# Altium schematic sheet CW521_BallisticGel_Main.SchDoc
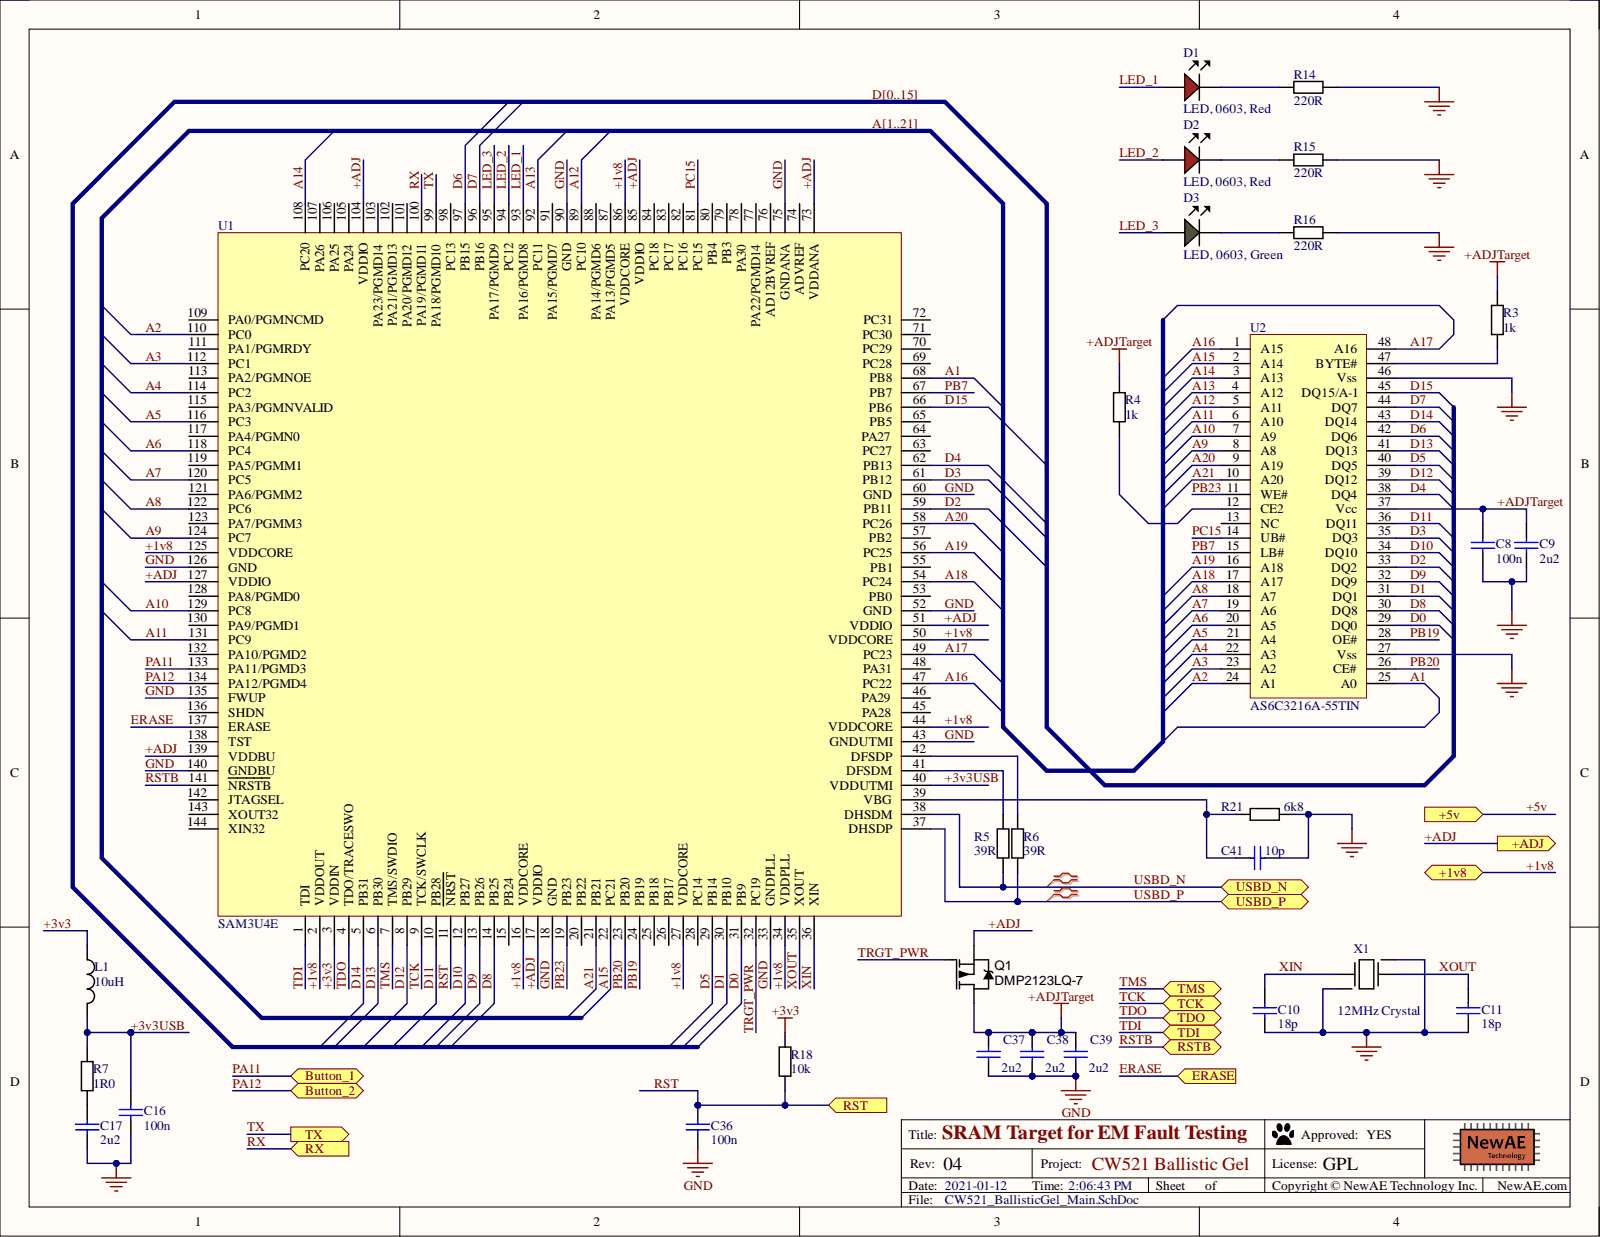
[diagram p0: CW521_BallisticGel_Main.SchDoc]

## Parts
C8  100n  [0603]
C9  2u2  [0603]
C10  18p  [0402]
C11  18p  [0402]
C16  100n  [0603]
C17  2u2  [0603]
C36  100n  [0603]
C37  2u2  [0603]
C38  2u2  [0603]
C39  2u2  [0603]
C41  10p  [0603]
D1  LED, 0603, Red  [LED-0603-RED]
D2  LED, 0603, Red  [LED-0603-RED]
D3  LED, 0603, Green  [LED-0603-GREEN]
L1  10uH  [0603]
Q1  DMP2123LQ-7  [SOT23]
R3  1k  [0603]
R4  1k  [0603]
R5  39R  [0603]
R6  39R  [0603]
R7  1R0  [0603]
R14  220R  [0603]
R15  220R  [0603]
R16  220R  [0603]
R18  10k  [0603]
R21  6k8  [0603]
U1  SAM3U4E  [LQFP144]
U2  AS6C3216A-55TIN  [TSSOP-48]
X1  12MHz Crystal  Component Group: Crystal  [ABM8G]

## Ports
+1v8, +5v, +ADJ, Button_1, Button_2, ERASE, RST, RSTB, RX, TCK, TDI, TDO, TMS, TX, USBD_N, USBD_P

## Nets
+1v8: U1.2(VDDOUT) U1.16(VDDCORE) U1.27(VDDCORE) U1.34(VDDPLL) U1.44(VDDCORE) U1.50(VDDCORE) U1.86(VDDCORE) U1.125(VDDCORE) ; port +1v8
+3v3: L1.1 R18.1 U1.3(VDDIN)
+3v3USB: C16.1 L1.2 R7.1 U1.40(VDDUTMI)
+ADJ: Q1.2 U1.17(VDDIO) U1.51(VDDIO) U1.73(VDDANA) U1.85(VDDIO) U1.104(VDDIO) U1.127(VDDIO) U1.139(VDDBU) ; port +ADJ
+ADJTarget: C8.1 C9.1 C37.1 C38.1 C39.1 Q1.3 R3.1 R4.1 U2.37(Vcc)
A1: U1.68(PB8) U2.25(A0)  [also labelled A]
A2: U1.110(PC0) U2.24(A1)  [also labelled A]
A3: U1.112(PC1) U2.23(A2)  [also labelled A]
A4: U1.114(PC2) U2.22(A3)  [also labelled A]
A5: U1.116(PC3) U2.21(A4)  [also labelled A]
A6: U1.118(PC4) U2.20(A5)  [also labelled A]
A7: U1.120(PC5) U2.19(A6)  [also labelled A]
A8: U1.122(PC6) U2.18(A7)  [also labelled A]
A9: U1.124(PC7) U2.8(A8)  [also labelled A]
A10: U1.129(PC8) U2.7(A9)  [also labelled A]
A11: U1.131(PC9) U2.6(A10)  [also labelled A]
A12: U1.89(PC10) U2.5(A11)  [also labelled A]
A13: U1.92(PC11) U2.4(A12)  [also labelled A]
A14: U1.108(PC20) U2.3(A13)  [also labelled A]
A15: U1.22(PC21) U2.2(A14)  [also labelled A]
A16: U1.47(PC22) U2.1(A15)  [also labelled A]
A17: U1.49(PC23) U2.48(A16)  [also labelled A]
A18: U1.54(PC24) U2.17(A17)  [also labelled A]
A19: U1.56(PC25) U2.16(A18)  [also labelled A]
A20: U1.58(PC26) U2.9(A19)  [also labelled A]
A21: U1.21(PB21) U2.10(A20)  [also labelled A]
D0: U1.31(PB9) U2.29(DQ0)  [also labelled D]
D1: U1.30(PB10) U2.31(DQ1)  [also labelled D]
D2: U1.59(PB11) U2.33(DQ2)  [also labelled D]
D3: U1.61(PB12) U2.35(DQ3)  [also labelled D]
D4: U1.62(PB13) U2.38(DQ4)  [also labelled D]
D5: U1.29(PB14) U2.40(DQ5)  [also labelled D]
D6: U1.97(PB15) U2.42(DQ6)  [also labelled D]
D7: U1.96(PB16) U2.44(DQ7)  [also labelled D]
D8: U1.14(PB25) U2.30(DQ8)  [also labelled D]
D9: U1.13(PB26) U2.32(DQ9)  [also labelled D]
D10: U1.12(PB27) U2.34(DQ10)  [also labelled D]
D11: U1.10(PB28) U2.36(DQ11)  [also labelled D]
D12: U1.8(PB29) U2.39(DQ12)  [also labelled D]
D13: U1.6(PB30) U2.41(DQ13)  [also labelled D]
D14: U1.5(PB31) U2.43(DQ14)  [also labelled D]
D15: U1.66(PB6) U2.45(DQ15/A-1)  [also labelled D]
ERASE: U1.137(ERASE) ; port ERASE
GND: C8.2 C9.2 C10.2 C11.2 C16.2 C17.2 C36.2 C37.2 C38.2 C39.2 C41.2 R14.2 R15.2 R16.2 R21.2 U1.18(GND) U1.33(GNDPLL) U1.43(GNDUTMI) U1.52(GND) U1.60(GND) U1.75(GNDANA) U1.90(GND) U1.126(GND) U1.135(FWUP) U1.140(GNDBU) U2.27(Vss) U2.46(Vss) X1.2 X1.4
LED_1: D1.A U1.93(PA16/PGMD8)
LED_2: D2.A U1.94(PC12)
LED_3: D3.A U1.95(PA17/PGMD9)
PA11: U1.133(PA11/PGMD3) ; port Button_1
PA12: U1.134(PA12/PGMD4) ; port Button_2
PB7: U1.67(PB7) U2.15(LB#)
PB19: U1.24(PB19) U2.28(OE#)
PB20: U1.23(PB20) U2.26(CE#)
PB23: U1.19(PB23) U2.11(WE#)
PC15: U1.81(PC15) U2.14(UB#)
RST: C36.1 R18.2 U1.11(NRST) ; port RST
RSTB: U1.141(NRSTB) ; port RSTB
RX: U1.100(PA19/PGMD11) ; port RX
TCK: U1.9(TCK/SWCLK) ; port TCK
TDI: U1.1(TDI) ; port TDI
TDO: U1.4(TDO/TRACESWO) ; port TDO
TMS: U1.7(TMS/SWDIO) ; port TMS
TRGT_PWR: Q1.1 U1.32(PC19)
TX: U1.99(PA18/PGMD10) ; port TX
USBD_N: R5.2 U1.38(DHSDM) ; port USBD_N
USBD_P: R6.2 U1.37(DHSDP) ; port USBD_P
XIN: C10.1 U1.36(XIN) X1.1
XOUT: C11.1 U1.35(XOUT) X1.3
NetC17_1: C17.1 R7.2
NetC41_1: C41.1 R21.1 U1.39(VBG)
NetD1_K: D1.K R14.1
NetD2_K: D2.K R15.1
NetD3_K: D3.K R16.1
NetR3_2: R3.2 U2.47(BYTE#)
NetR4_2: R4.2 U2.12(CE2)
NetR5_1: R5.1 U1.41(DFSDM)
NetR6_1: R6.1 U1.42(DFSDP)
+5v: ; port +5v

Not connected: U1 15(PB24) 20(PB22) 25(PB18) 26(PB17) 28(PC14) 45(PA28) 46(PA29) 48(PA31) 53(PB0) 55(PB1) 57(PB2) 63(PC27) 64(PA27) 65(PB5) 69(PC28) 70(PC29) 71(PC30) 72(PC31) 74(ADVREF) 76(AD12BVREF) 77(PA22/PGMD14) 78(PA30) 79(PB3) 80(PB4) 82(PC16) 83(PC17) 84(PC18) 87(PA13/PGMD5) 88(PA14/PGMD6) 91(PA15/PGMD7) 98(PC13) 101(PA20/PGMD12) 102(PA21/PGMD13) 103(PA23/PGMD14) 105(PA24) 106(PA25) 107(PA26) 109(PA0/PGMNCMD) 111(PA1/PGMRDY) 113(PA2/PGMNOE) 115(PA3/PGMNVALID) 117(PA4/PGMN0) 119(PA5/PGMM1) 121(PA6/PGMM2) 123(PA7/PGMM3) 128(PA8/PGMD0) 130(PA9/PGMD1) 132(PA10/PGMD2) 136(SHDN) 138(TST) 142(JTAGSEL) 143(XOUT32) 144(XIN32); U2 13(NC)

## Library data of the parts
C8 C16 C36 = CCHIP-100n-0603: 100n, 0603; Manufacture 1: Kemet; Manufacture Part Number 1: C0603C104K5RACTU; Case-Metric: 1608; Component Group: Capacitor; Database Table Name: main; Rated Voltage: 50V; Case-EIA: 0603; Component Kind: Standard; Component Type: Standard; Library Name: NewAE_AltiumLib.DBLib; Mounting Technology: SMT; Part Number: CCHIP-100n-0603; Pin Count: 2; Supplier 1: Digi-Key; Supplier Part Number 1: 399-5089-2-ND; Value: 100n
C9 C17 C37-C39 = CCHIP-2u2-0603: 2u2, 0603; Manufacture 1: Samsung; Manufacture Part Number 1: CL10B225KP8NNNC; Case-Metric: 1608; Component Group: Capacitor; Database Table Name: main; Case-EIA: 0603; Component Kind: Standard; Component Type: Standard; Library Name: NewAE_AltiumLib.DBLib; Mounting Technology: SMT; Part Number: CCHIP-2u2-0603; Pin Count: 2; Supplier 1: Digi-Key; Supplier Part Number 1: 1276-1134-2-ND; Value: 2u2
C10 C11 = CCHIP-18p-0402: 18p, 0402; Manufacture Part Number 1: GRM1555C1H180JA01D; Component Group: Capacitor; Database Table Name: main; Case-EIA: 0402; Component Kind: Standard; Component Type: Standard; Library Name: NewAE_AltiumLib.DBLib; Mounting Technology: SMT; Part Number: CCHIP-18p-0402; Pin Count: 2; Value: 18p
C41 = CCHIP-10p-0603: 10p, 0603; Case-Metric: 1608; Component Group: Capacitor; Database Table Name: main; Case-EIA: 0603; Component Kind: Standard; Component Type: Standard; Library Name: NewAE_AltiumLib.DBLib; Mounting Technology: SMT; Part Number: CCHIP-10p-0603; Pin Count: 2; Value: 10p
D1 D2 = LEDCHIP_0603_RED: Chip LED, Red; Manufacture 1: Kingbright; Manufacture Part Number 1: APT1608SRCPRV; Component Group: Optical; Database Table Name: main; PP Material Stack: 15; PP Rotation: -90; Component Kind: Standard; Component Type: Standard; Library Name: NewAE_AltiumLib.DBLib; Mounting Technology: SMT; Part Number: LEDCHIP_0603_RED; Pin Count: 2; Supplier 1: Digi-Key; Supplier Part Number 1: 754-1122-1-ND
D3 = LEDCHIP_0603_GREEN: Chip LED, Green; Manufacture 1: Kingbright; Manufacture Part Number 1: APT1608CGCK; Component Group: Optical; Database Table Name: main; PP Material Stack: 16; PP Rotation: -90; Component Kind: Standard; Component Type: Standard; Library Name: NewAE_AltiumLib.DBLib; Mounting Technology: SMT; Part Number: LEDCHIP_0603_GREEN; Pin Count: 2; Supplier 1: Digi-Key; Supplier Part Number 1: 754-1116-1-ND
L1 = 10uH_0603: FIXED IND 10UH 300MA 600 MOHM; Manufacture 1: TDK; Manufacture Part Number 1: MLZ1608N100LT000; Component Group: Inductor; Database Table Name: main; PP Material Stack: 0; Component Kind: Standard; Component Type: Standard; Library Name: NewAE_AltiumLib.DBLib; Mounting Technology: SMT; Part Number: 10uH_0603; Pin Count: 2; PP Rotation: 0; RoHS: Yes; Supplier 1: Digi-Key; Supplier Part Number 1: 445-6755-1-ND; Value: 10uH
Q1 = DMP2123LQ-7: P-Channel, 3A, Logic Level; Manufacture 1: Diodes Inc.; Component Group: MOSFET; Database Table Name: main; Component Kind: Standard; Component Type: Standard; Library Name: NewAE_AltiumLib.DBLib; Manufacture Part Number 1: DMP2123LQ-7; Mounting Technology: SMT; Part Number: DMP2123LQ-7; Pin Count: 3; Supplier 1: Digi-Key; Supplier Part Number 1: DMP2123LQ-7DICT-ND
R3 R4 = RCHIP-1K-0603: 1k res, 0603, 1%, 1/10W; Manufacture 1: Yageo; Manufacture Part Number 1: RC0603FR-071KL; Case-Metric: 1608; Component Group: Resistor; Database Table Name: main; Rated Power: 0.1W; Rated Voltage: 75VDC; Case-EIA: 0603; Component Kind: Standard; Component Type: Standard; Library Name: NewAE_AltiumLib.DBLib; Mounting Technology: SMT; Part Number: RCHIP-1K-0603; Pin Count: 2; Supplier 1: Digi-Key; Supplier Part Number 1: 311-1.00KHRCT-ND; Temperature Range: -55C to 155C; Tolerance: 1%; Value: 1k
R5 R6 = RCHIP-39R-0603: 39R res, 0603, 1%, 1/10W; Manufacture 1: Yageo; Manufacture Part Number 1: RC0603FR-0739RL; Case-Metric: 1608; Component Group: Resistor; Database Table Name: main; Rated Power: 0.1W; Rated Voltage: 75VDC; Case-EIA: 0603; Component Kind: Standard; Component Type: Standard; Library Name: NewAE_AltiumLib.DBLib; Mounting Technology: SMT; Part Number: RCHIP-39R-0603; Pin Count: 2; Supplier 1: Digi-Key; Supplier Part Number 1: 311-39.0HRCT-ND; Temperature Range: -55C to 155C; Tolerance: 1%; Value: 39R
R7 = RCHIP-1R0-0603: 1R0 res, 0603, 1%, 1/10W; Manufacture 1: Yageo; Manufacture Part Number 1: RC0603FR-071RL; Case-Metric: 1608; Component Group: Resistor; Database Table Name: main; Rated Power: 0.1W; Rated Voltage: 75VDC; Case-EIA: 0603; Component Kind: Standard; Component Type: Standard; Library Name: NewAE_AltiumLib.DBLib; Mounting Technology: SMT; Part Number: RCHIP-1R0-0603; Pin Count: 2; Supplier 1: Digi-Key; Supplier Part Number 1: 311-1.00HRCT-ND; Temperature Range: -55C to 155C; Tolerance: 1%; Value: 1R0
R14-R16 = RCHIP-220R-0603: 220R res, 0603, 1%, 1/10W; Manufacture 1: Yageo; Manufacture Part Number 1: RC0603FR-07220RL; Case-Metric: 1608; Component Group: Resistor; Database Table Name: main; Rated Power: 0.1W; Rated Voltage: 75VDC; Case-EIA: 0603; Component Kind: Standard; Component Type: Standard; Library Name: NewAE_AltiumLib.DBLib; Mounting Technology: SMT; Part Number: RCHIP-220R-0603; Pin Count: 2; Supplier 1: Digi-Key; Supplier Part Number 1: 311-220HRCT-ND; Temperature Range: -55C to 155C; Tolerance: 1%; Value: 220R
R18 = RCHIP-10K-0603: 10k res, 0603, 1%, 1/10W; Manufacture 1: Yageo; Manufacture Part Number 1: RC0603FR-0710KL; Case-Metric: 1608; Component Group: Resistor; Database Table Name: main; Rated Power: 0.1W; Rated Voltage: 75VDC; Case-EIA: 0603; Component Kind: Standard; Component Type: Standard; Library Name: NewAE_AltiumLib.DBLib; Mounting Technology: SMT; Part Number: RCHIP-10K-0603; Pin Count: 2; Supplier 1: Digi-Key; Supplier Part Number 1: 311-10.0KHRCT-ND; Temperature Range: -55C to 155C; Tolerance: 1%; Value: 10k
R21 = RCHIP-6K8-0603: 6k8 res, 0603, 1%, 1/10W; Manufacture 1: Yageo; Manufacture Part Number 1: RC0603FR-076K8L; Case-Metric: 1608; Component Group: Resistor; Database Table Name: main; Rated Power: 0.1W; Rated Voltage: 75VDC; Case-EIA: 0603; Component Kind: Standard; Component Type: Standard; Library Name: NewAE_AltiumLib.DBLib; Mounting Technology: SMT; Part Number: RCHIP-6K8-0603; Pin Count: 2; Supplier 1: Digi-Key; Supplier Part Number 1: 311-6.80KHRCT-ND; Temperature Range: -55C to 155C; Tolerance: 1%; Value: 6k8
U1 = SAM3U4E: IC MCU 32BIT 256KB FLASH 144LQFP; Manufacture 1: Microchip; Manufacture Part Number 1: ATSAM3U4EA-AU  ATSAM3U4EA-AU; Component Group: Digital IC; Database Table Name: main; PP Material Stack: 0; Component Kind: Standard; Component Type: Standard; Library Name: NewAE_AltiumLib.DBLib; Mounting Technology: SMT; Part Number: SAM3U4E; Pin Count: 144; PP Rotation: 0; RoHS: Yes; Supplier 1: Digi-Key; Supplier Part Number 1: ATSAM3U4EA-AU-ND
U2 = AS6C3216: IC SRAM 32MBIT 55NS 48TSSOP; Manufacture 1: Alliance Memory; Component Group: Digital IC; Database Table Name: main; PP Material Stack: 0; Component Kind: Standard; Component Type: Standard; Library Name: NewAE_AltiumLib.DBLib; Manufacture Part Number 1: AS6C3216A-55TIN; Mounting Technology: SMT; Part Number: AS6C3216A; Pin Count: 48; PP Rotation: 0; RoHS: Yes; Supplier 1: Digi-Key
X1 = ABM8G: CRYSTAL 12.0000MHZ 10PF SMD; Manufacture 1: Abracon LLC; Manufacture Part Number 1: ABM8G-12.000MHZ-4Y-T3; Database Table Name: main; PP Material Stack: 0; Component Kind: Standard; Component Type: Standard; Library Name: NewAE_AltiumLib.DBLib; Mounting Technology: SMT; Part Number: ABM8G; Pin Count: 4; PP Rotation: 0; RoHS: Yes; Supplier 1: Digi-Key; Supplier Part Number 1: 535-10901-1-ND
